# Altium schematic sheet EEG_main.SchDoc(EEG_main)
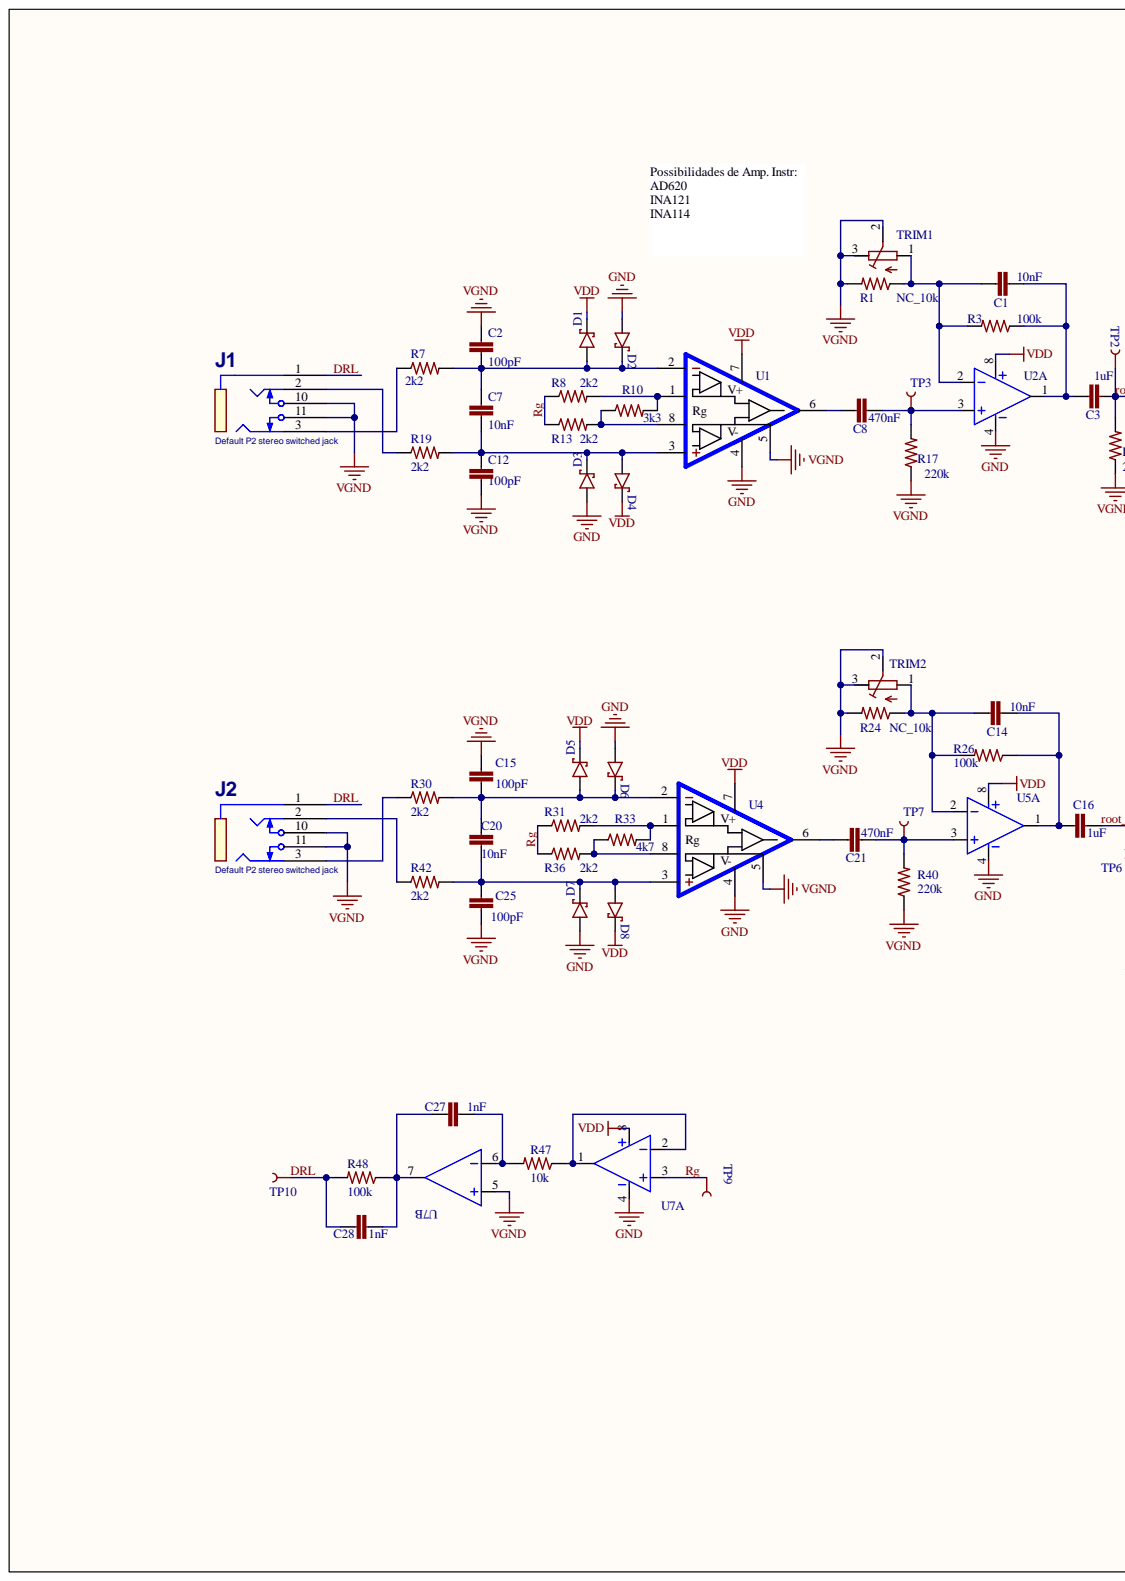
[diagram p0: EEG_main.SchDoc, left]
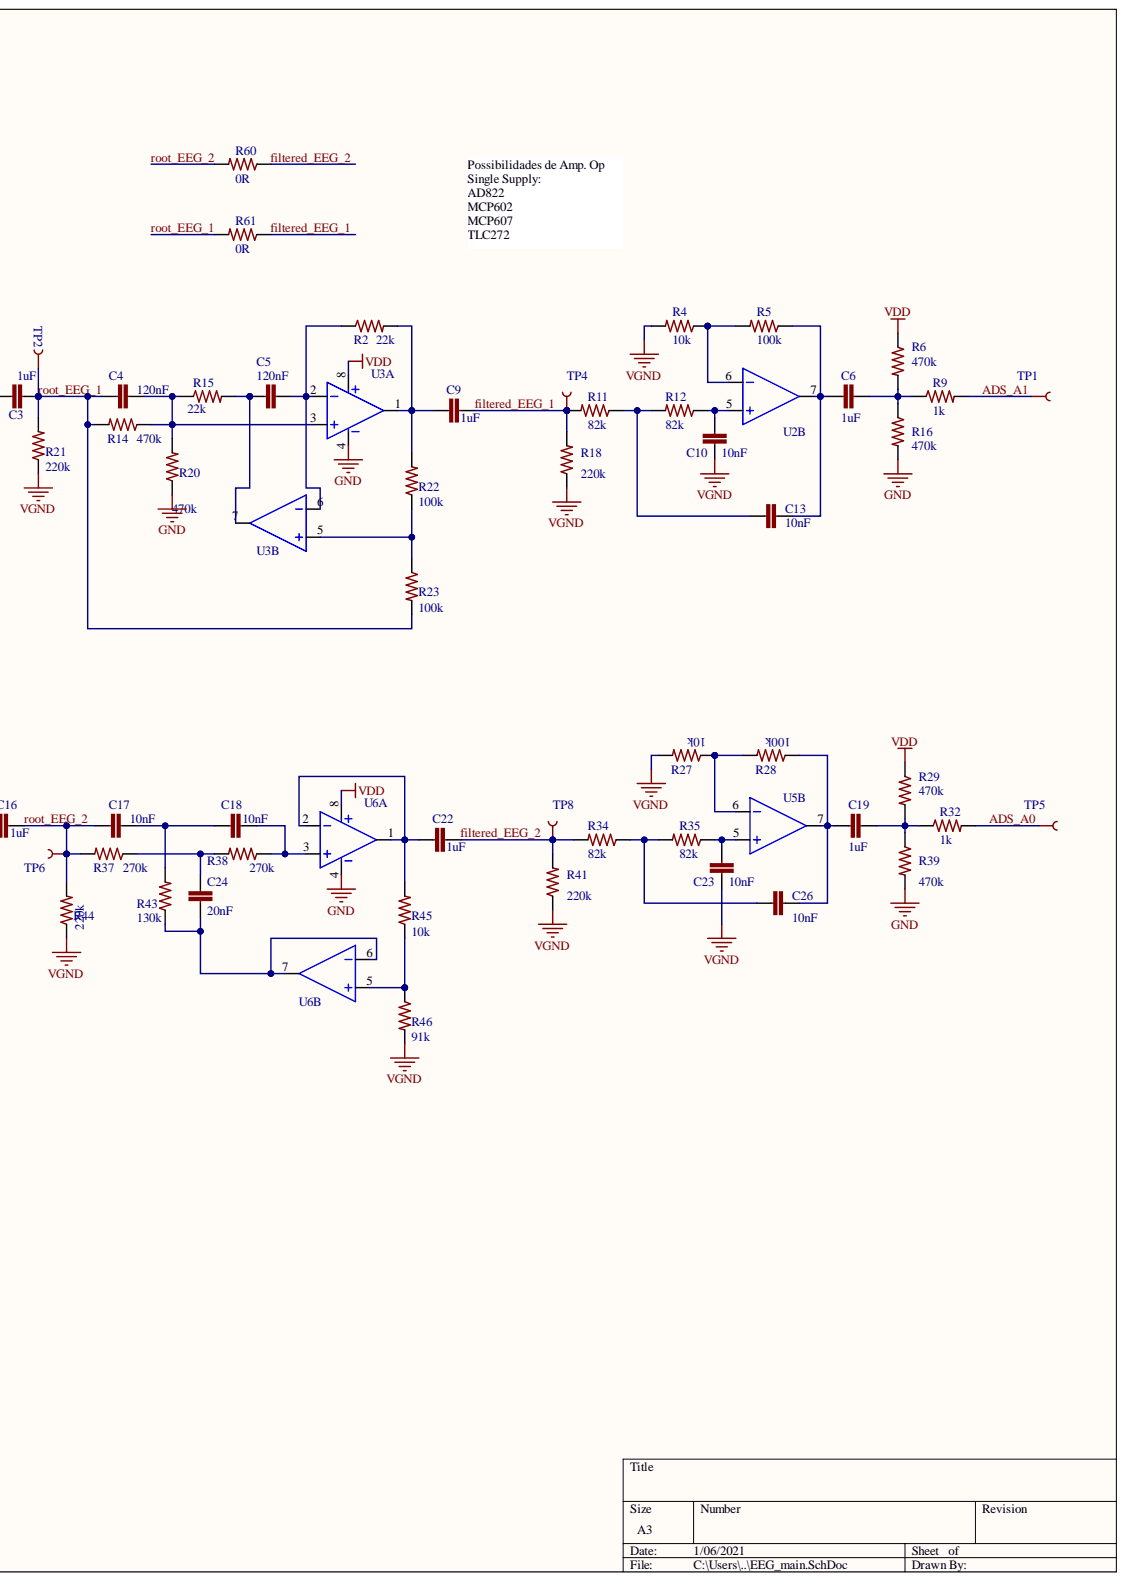
[diagram p1: EEG_main.SchDoc, right]

## Parts
C1  10nF  [C0805 - rounded]
C2  100pF  [C0805 - rounded]
C3  1uF  [C0805 - rounded]
C4  120nF  [C0805 - rounded]
C5  120nF  [C0805 - rounded]
C6  1uF  [C0805 - rounded]
C7  10nF  [C0805 - rounded]
C8  470nF  [C0805 - rounded]
C9  1uF  [C0805 - rounded]
C10  10nF  [C0805 - rounded]
C12  100pF  [C0805 - rounded]
C13  10nF  [C0805 - rounded]
C14  10nF  [C0805 - rounded]
C15  100pF  [C0805 - rounded]
C16  1uF  [C0805 - rounded]
C17  10nF  [C0805 - rounded]
C18  10nF  [C0805 - rounded]
C19  1uF  [C0805 - rounded]
C20  10nF  [C0805 - rounded]
C21  470nF  [C0805 - rounded]
C22  1uF  [C0805 - rounded]
C23  10nF  [C0805 - rounded]
C24  20nF  [C0805 - rounded]
C25  100pF  [C0805 - rounded]
C26  10nF  [C0805 - rounded]
C27  1nF  [C0805 - rounded]
C28  1nF  [C0805 - rounded]
D1  DIODE  [DO214AA]  (value not drawn)
D2  DIODE  [DO214AA]  (value not drawn)
D3  DIODE  [DO214AA]  (value not drawn)
D4  DIODE  [DO214AA]  (value not drawn)
D5  DIODE  [DO214AA]  (value not drawn)
D6  DIODE  [DO214AA]  (value not drawn)
D7  DIODE  [DO214AA]  (value not drawn)
D8  DIODE  [DO214AA]  (value not drawn)
J1  Default P2 stereo switched jack  [phone jack - SJ1-352x]
J2  Default P2 stereo switched jack  [phone jack - SJ1-352x]
R1  NC_10k  [R0805 - rounded]
R2  22k  [R0805 - rounded]
R3  100k  [R0805 - rounded]
R4  10k  [R0805 - rounded]
R5  100k  [R0805 - rounded]
R6  470k  [R0805 - rounded]
R7  2k2  [R0805 - rounded]
R8  2k2  [R0805 - rounded]
R9  1k  [R0805 - rounded]
R10  3k3  [R0805 - rounded]
R11  82k  [R0805 - rounded]
R12  82k  [R0805 - rounded]
R13  2k2  [R0805 - rounded]
R14  470k  [R0805 - rounded]
R15  22k  [R0805 - rounded]
R16  470k  [R0805 - rounded]
R17  220k  [R0805 - rounded]
R18  220k  [R0805 - rounded]
R19  2k2  [R0805 - rounded]
R20  470k  [R0805 - rounded]
R21  220k  [R0805 - rounded]
R22  100k  [R0805 - rounded]
R23  100k  [R0805 - rounded]
R24  NC_10k  [R0805 - rounded]
R26  100k  [R0805 - rounded]
R27  10k  [R0805 - rounded]
R28  100k  [R0805 - rounded]
R29  470k  [R0805 - rounded]
R30  2k2  [R0805 - rounded]
R31  2k2  [R0805 - rounded]
R32  1k  [R0805 - rounded]
R33  4k7  [R0805 - rounded]
R34  82k  [R0805 - rounded]
R35  82k  [R0805 - rounded]
R36  2k2  [R0805 - rounded]
R37  270k  [R0805 - rounded]
R38  270k  [R0805 - rounded]
R39  470k  [R0805 - rounded]
R40  220k  [R0805 - rounded]
R41  220k  [R0805 - rounded]
R42  2k2  [R0805 - rounded]
R43  130k  [R0805 - rounded]
R44  220k  [R0805 - rounded]
R45  10k  [R0805 - rounded]
R46  91k  [R0805 - rounded]
R47  10k  [R0805 - rounded]
R48  100k  [R0805 - rounded]
R60  0R  [R0805 - rounded]
R61  0R  [R0805 - rounded]
TP1  SparkFun Test Points    Bare copper test points for troubleshooting or In-Circuit-Testing. These are used by our Production team for verifying production board runs using pogo-pins on test beds.   SparkFun Products: SparkFun LSM9DS1 Breakout  SparkFun PSOC  SparkFun Load Cell Amplifier  [PAD.03X.03]  (value not drawn)
TP2  SparkFun Test Points    Bare copper test points for troubleshooting or In-Circuit-Testing. These are used by our Production team for verifying production board runs using pogo-pins on test beds.   SparkFun Products: SparkFun LSM9DS1 Breakout  SparkFun PSOC  SparkFun Load Cell Amplifier  [PAD.03X.03]  (value not drawn)
TP3  SparkFun Test Points    Bare copper test points for troubleshooting or In-Circuit-Testing. These are used by our Production team for verifying production board runs using pogo-pins on test beds.   SparkFun Products: SparkFun LSM9DS1 Breakout  SparkFun PSOC  SparkFun Load Cell Amplifier  [PAD.03X.03]  (value not drawn)
TP4  SparkFun Test Points    Bare copper test points for troubleshooting or In-Circuit-Testing. These are used by our Production team for verifying production board runs using pogo-pins on test beds.   SparkFun Products: SparkFun LSM9DS1 Breakout  SparkFun PSOC  SparkFun Load Cell Amplifier  [PAD.03X.03]  (value not drawn)
TP5  SparkFun Test Points    Bare copper test points for troubleshooting or In-Circuit-Testing. These are used by our Production team for verifying production board runs using pogo-pins on test beds.   SparkFun Products: SparkFun LSM9DS1 Breakout  SparkFun PSOC  SparkFun Load Cell Amplifier  [PAD.03X.03]  (value not drawn)
TP6  SparkFun Test Points    Bare copper test points for troubleshooting or In-Circuit-Testing. These are used by our Production team for verifying production board runs using pogo-pins on test beds.   SparkFun Products: SparkFun LSM9DS1 Breakout  SparkFun PSOC  SparkFun Load Cell Amplifier  [PAD.03X.03]  (value not drawn)
TP7  SparkFun Test Points    Bare copper test points for troubleshooting or In-Circuit-Testing. These are used by our Production team for verifying production board runs using pogo-pins on test beds.   SparkFun Products: SparkFun LSM9DS1 Breakout  SparkFun PSOC  SparkFun Load Cell Amplifier  [PAD.03X.03]  (value not drawn)
TP8  SparkFun Test Points    Bare copper test points for troubleshooting or In-Circuit-Testing. These are used by our Production team for verifying production board runs using pogo-pins on test beds.   SparkFun Products: SparkFun LSM9DS1 Breakout  SparkFun PSOC  SparkFun Load Cell Amplifier  [PAD.03X.03]  (value not drawn)
TP9  SparkFun Test Points    Bare copper test points for troubleshooting or In-Circuit-Testing. These are used by our Production team for verifying production board runs using pogo-pins on test beds.   SparkFun Products: SparkFun LSM9DS1 Breakout  SparkFun PSOC  SparkFun Load Cell Amplifier  [PAD.03X.03]  (value not drawn)
TP10  SparkFun Test Points    Bare copper test points for troubleshooting or In-Circuit-Testing. These are used by our Production team for verifying production board runs using pogo-pins on test beds.   SparkFun Products: SparkFun LSM9DS1 Breakout  SparkFun PSOC  SparkFun Load Cell Amplifier  [PAD.03X.03]  (value not drawn)
TRIM1  R-TRIMM  [RTRIM3386]  (value not drawn)
TRIM2  R-TRIMM  [RTRIM3386]  (value not drawn)
U1  AD620  [SOIC8]  (value not drawn)
U2  MCP602  [SOIC8]  (value not drawn)  (parts A,B)
U3  MCP602  [SOIC8]  (value not drawn)  (parts A,B)
U4  AD620  [SOIC8]  (value not drawn)
U5  MCP602  [SOIC8]  (value not drawn)  (parts A,B)
U6  MCP602  [SOIC8]  (value not drawn)  (parts A,B)
U7  MCP602  [SOIC8]  (value not drawn)  (parts A,B)

## Nets
GND: D2.A D3.A D6.A D7.A R16.2 R20.1 R39.2 U1.4 U2.4 U3.4 U4.4 U5.4 U6.4 U7.4
VDD: D1.C D4.C D5.C D8.C R6.1 R29.1 U1.7(V+) U2.8 U3.8 U4.7(V+) U5.8 U6.8 U7.8
VGND: C2.2 C10.1 C12.2 C15.2 C23.1 C25.2 J1.10 J1.11 J2.10 J2.11 R1.2 R4.2 R17.1 R18.1 R21.1 R24.2 R27.2 R40.1 R41.1 R44.1 R46.2 TRIM1.2 TRIM1.3 TRIM2.2 TRIM2.3 U1.5 U4.5 U7.5
ADS_A0: R32.2 TP5.P$1
ADS_A1: R9.2 TP1.P$1
DRL: C28.1 J1.1 J2.1 R48.2 TP10.P$1
filtered_EEG_1: C9.2 R11.2 R18.2 R61.1 TP4.P$1
filtered_EEG_2: C22.2 R34.2 R41.2 R60.1 TP8.P$1
Rg: R8.1 R13.1 R31.1 R36.1 TP9.P$1 U7.3
root_EEG_1: C3.2 C4.1 R14.2 R21.2 R23.2 R61.2 TP2.P$1
root_EEG_2: C16.2 C17.1 R37.2 R44.2 R60.2 TP6.P$1
NetC1_1: C1.1 R1.1 R3.2 TRIM1.1 U2.2
NetC1_2: C1.2 C3.1 R3.1 U2.1
NetC2_1: C2.1 C7.2 D1.A D2.C R7.2 U1.2
NetC4_2: C4.2 R14.1 R15.1 R20.2 U3.3
NetC5_1: C5.1 R15.2 U3.7
NetC5_2: C5.2 R2.1 U3.2 U3.6
NetC6_1: C6.1 C13.1 R5.1 U2.7
NetC6_2: C6.2 R6.2 R9.1 R16.1
NetC7_1: C7.1 C12.1 D3.C D4.A R19.2 U1.3
NetC8_1: C8.1 U1.6
NetC8_2: C8.2 R17.2 TP3.P$1 U2.3
NetC9_1: C9.1 R2.2 R22.2 U3.1
NetC10_2: C10.2 R12.1 U2.5
NetC13_2: C13.2 R11.1 R12.2
NetC14_1: C14.1 R24.1 R26.2 TRIM2.1 U5.2
NetC14_2: C14.2 C16.1 R26.1 U5.1
NetC15_1: C15.1 C20.2 D5.A D6.C R30.2 U4.2
NetC17_2: C17.2 C18.1 R43.2
NetC18_2: C18.2 R38.1 U6.3
NetC19_1: C19.1 C26.1 R28.1 U5.7
NetC19_2: C19.2 R29.2 R32.1 R39.1
NetC20_1: C20.1 C25.1 D7.C D8.A R42.2 U4.3
NetC21_1: C21.1 U4.6
NetC21_2: C21.2 R40.2 TP7.P$1 U5.3
NetC22_1: C22.1 R45.2 U6.1 U6.2
NetC23_2: C23.2 R35.1 U5.5
NetC24_1: C24.1 R43.1 U6.6 U6.7
NetC24_2: C24.2 R37.1 R38.2
NetC26_2: C26.2 R34.1 R35.2
NetC27_1: C27.1 C28.2 R48.1 U7.7
NetC27_2: C27.2 R47.2 U7.6
NetJ1_2: J1.2 R19.1
NetJ1_3: J1.3 R7.1
NetJ2_2: J2.2 R42.1
NetJ2_3: J2.3 R30.1
NetR4_1: R4.1 R5.2 U2.6
NetR8_2: R8.2 R10.2 U1.1
NetR10_1: R10.1 R13.2 U1.8
NetR22_1: R22.1 R23.1 U3.5
NetR27_1: R27.1 R28.2 U5.6
NetR31_2: R31.2 R33.2 U4.1
NetR33_1: R33.1 R36.2 U4.8
NetR45_1: R45.1 R46.1 U6.5
NetR47_1: R47.1 U7.1 U7.2

## Library data of the parts
C1-C10 C12-C28 = C-EU-SMD: Generic Capacitor SMD; Component Kind: Standard; Library Name: rcl.SCHLIB
  C1 C7 C10 C13 C14 C17 C18 C20 C23 C26: Value: 10nF
  C2 C12 C15 C25: Value: 100pF
  C3 C6 C9 C16 C19 C22: Value: 1uF
  C4 C5: Value: 120nF
  C8 C21: Value: 470nF
  C24: Value: 20nF
  C27 C28: Value: 1nF
D1-D8 = SCHOTTKY-DIODE: Part Number: SS12; Component Kind: Standard; Library Name: diode.IntLib
J1 J2 = PHONEJACK - SJ1-352x: Component Kind: Standard; Library Name: Misc.IntLib
R1-R24 R26-R48 R60 R61 = R-US-SMD: Generic Resistor SMD; Component Kind: Standard; Library Name: rcl.SCHLIB
  R1 R24: Value: NC_10k
  R2 R15: Value: 22k
  R3 R5 R22 R23 R26 R28 R48: Value: 100k
  R4 R27 R45 R47: Value: 10k
  R6 R14 R16 R20 R29 R39: Value: 470k
  R7 R8 R13 R19 R30 R31 R36 R42: Value: 2k2
  R9 R32: Value: 1k
  R10: Value: 3k3
  R11 R12 R34 R35: Value: 82k
  R17 R18 R21 R40 R41 R44: Value: 220k
  R33: Value: 4k7
  R37 R38: Value: 270k
  R43: Value: 130k
  R46: Value: 91k
  R60 R61: Value: 0R
TP1-TP10 = TEST-POINT2: Component Kind: Standard; Library Name: Misc.IntLib
TRIM1 TRIM2 = R-TRIMM: Value: 100k; Component Kind: Standard; Library Name: rcl.SCHLIB
U1 U4 = AD620: Low Cost Low Power Instrumentation Amplifier; Component Kind: Standard; Library Name: amplifiers.IntLib
U2 U3 U5 U6 = MCP602: Operational Amplifiers - Op Amps Dual 2.7V; Vendor: Microchip; Component Kind: Standard; Library Name: amplifiers.IntLib; Part Number: MCP602
U7 = TL082: Wide Bandwidth Dual JFET Input Op Amp; Component Kind: Standard; Library Name: amplifiers.IntLib; Part Number: MCP602
